# Altium schematic sheet SMP.SchDoc(SMP)
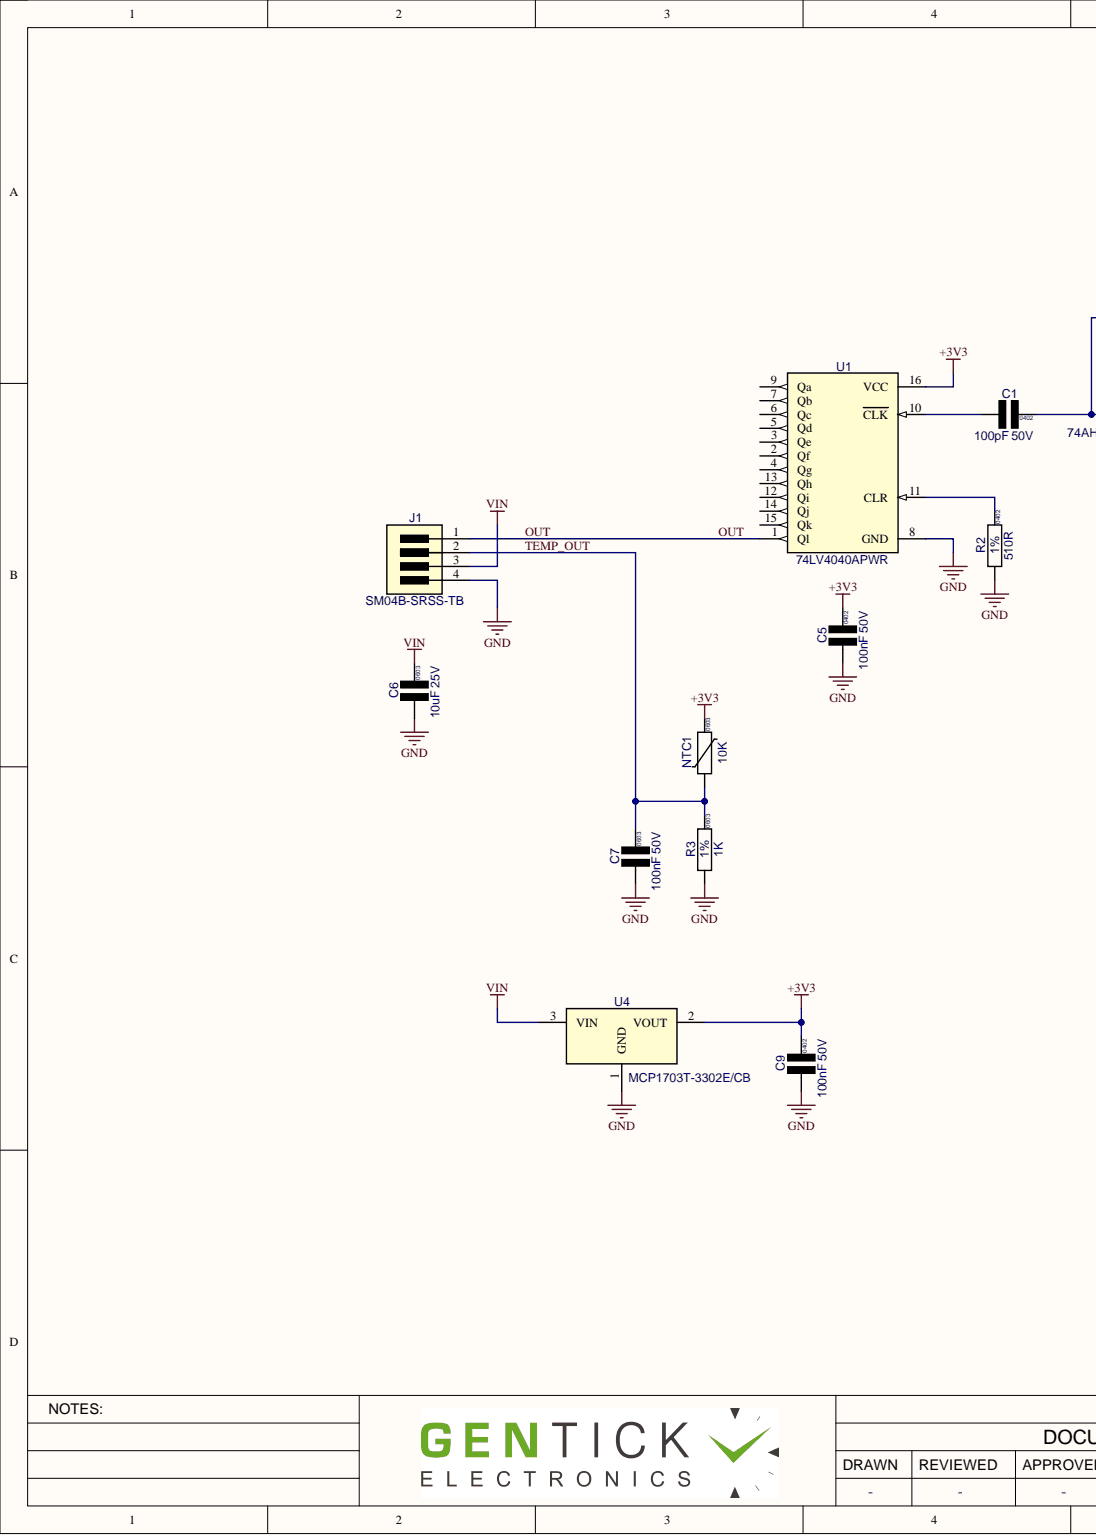
[diagram p0: SMP.SchDoc, left]
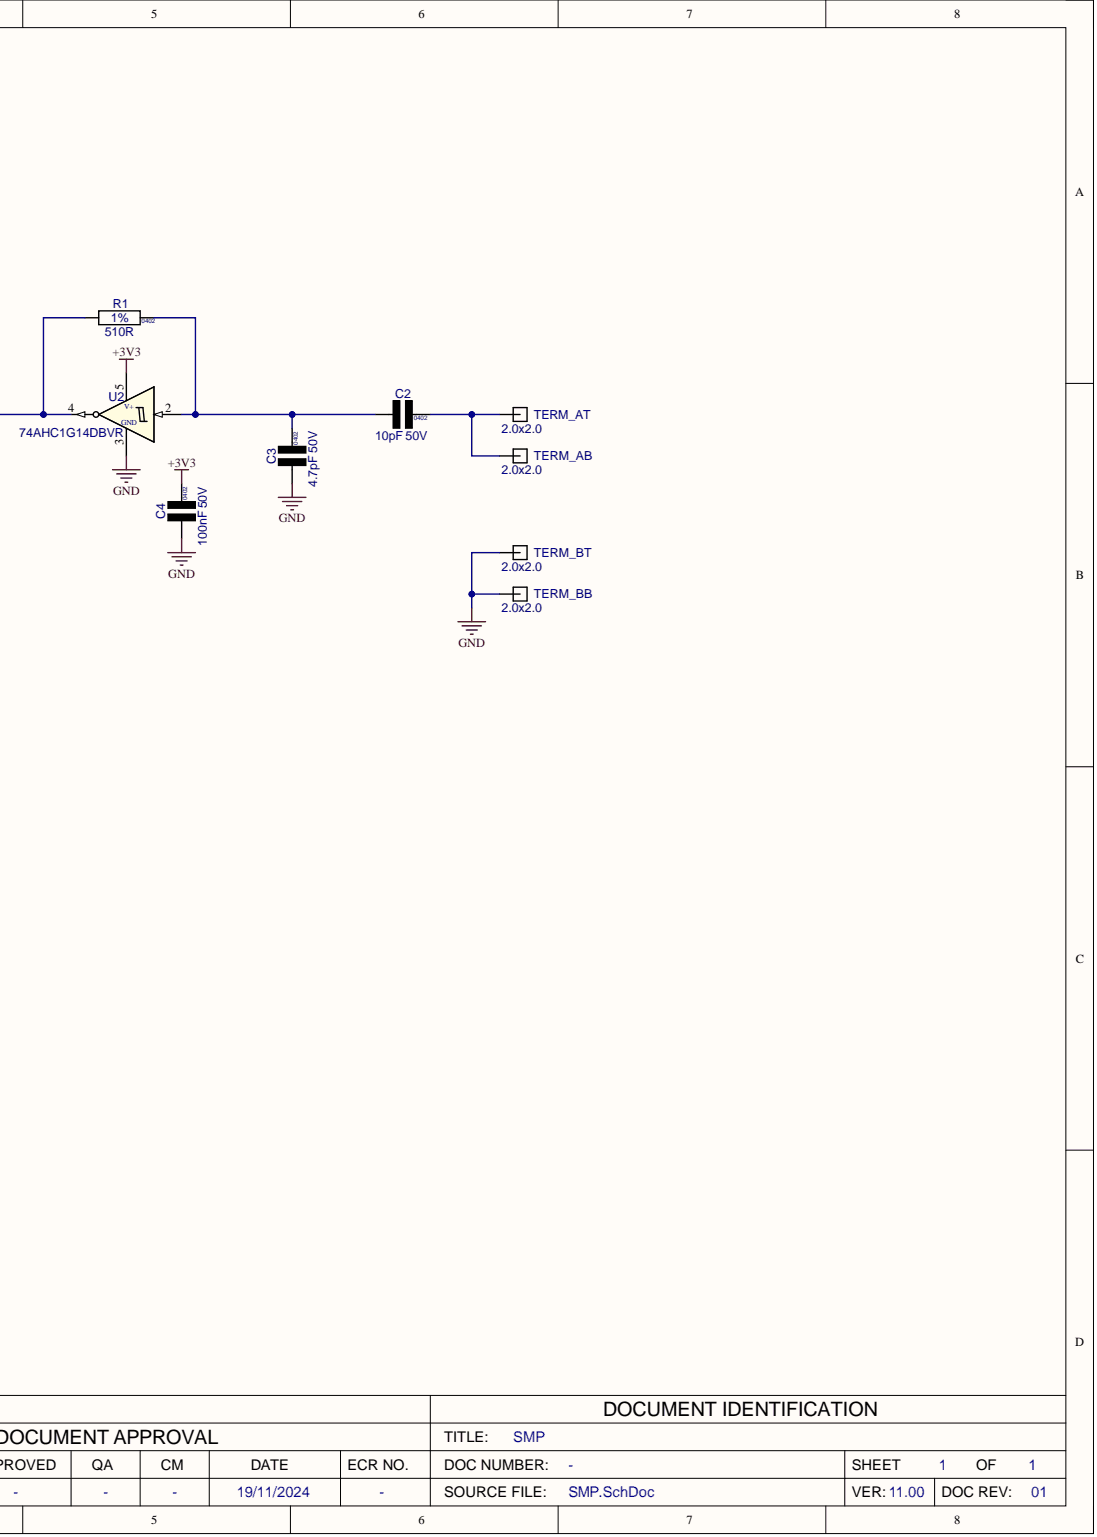
[diagram p1: SMP.SchDoc, right]

## Parts
C1  value 100pF  Text1: 0402  Voltage: 50V  [CAPC0402X60N]  (hidden comment: 100pF_C0402_50V_10%_C0G/NP0)
C2  value 10pF  Text1: 0402  Voltage: 50V  [CAPC0402X60N]  (hidden comment: 10pF_C0402_50V_5%_C0G/NP0)
C3  value 4.7pF  Text1: 0402  Voltage: 50V  [CAPC0402X60N]  (hidden comment: 4.7pF_C0402_50V_5%_C0G/NP0)
C4  value 100nF  Text1: 0402  Voltage: 50V  [CAPC0402X60N]  (hidden comment: 100nF_C0402_50V_5%_X5R)
C5  value 100nF  Text1: 0402  Voltage: 50V  [CAPC0402X60N]  (hidden comment: 100nF_C0402_50V_5%_X5R)
C6  value 10uF  Text1: 0603  Voltage: 25V  [CAPC0603X85N]  (hidden comment: 10uF_C0603_25V_10%_X5R)
C7  value 100nF  Text1: 0603  Voltage: 50V  [CAPC0603X85N]  (hidden comment: 100nF_C0603_50V_5%_X5R)
C9  value 100nF  Text1: 0402  Voltage: 50V  [CAPC0402X60N]  (hidden comment: 100nF_C0402_50V_5%_X5R)
J1  SM04B-SRSS-TB  [SM04B-SRSS-TB]
NTC1  value 10K  Text1: 0603  [NTC0603]  (hidden comment: ERT-J1VG103FA)
R1  value 510R  Part Number: 510R 0402 1%  Text1: 0402  Tol: 1%  [RESC0402X40N]  (hidden comment: 510R_R0402_1%)
R2  value 510R  Part Number: 510R 0402 1%  Text1: 0402  Tol: 1%  [RESC0402X40N]  (hidden comment: 510R_R0402_1%)
R3  value 1K  Part Number: 1K 0603 1%  Text1: 0603  Tol: 1%  [RESC0603X60N]  (hidden comment: 1K_R0603_1%)
TERM_AB  Part Number: 2.0x2.0  [PAD-SMD-2.0x2.0mm Rect]  (hidden comment: Pad-SMD-2.0x2.0mm-H_Rectangle)  (no BOM)
TERM_AT  Part Number: 2.0x2.0  [PAD-SMD-2.0x2.0mm Rect]  (hidden comment: Pad-SMD-2.0x2.0mm-H_Rectangle)  (no BOM)
TERM_BB  Part Number: 2.0x2.0  [PAD-SMD-2.0x2.0mm Rect]  (hidden comment: Pad-SMD-2.0x2.0mm-H_Rectangle)  (no BOM)
TERM_BT  Part Number: 2.0x2.0  [PAD-SMD-2.0x2.0mm Rect]  (hidden comment: Pad-SMD-2.0x2.0mm-H_Rectangle)  (no BOM)
U1  74LV4040APWR  [TSSOP16-4.5x5.0]
U2  74AHC1G14DBVR  [SOT23-5]
U4  MCP1703T-3302E/CB  [SOT23A]

## Nets
+3V3: C4.1 C5.1 C9.1 NTC1.2 U1.16(VCC) U2.5(V+) U4.2
GND: C3.2 C4.2 C5.2 C6.2 C7.2 C9.2 J1.4 R2.1 R3.1 TERM_BB.1 TERM_BT.1 U1.8(GND) U2.3 U4.1
VIN: C6.1 J1.3 U4.3
OUT: J1.1 U1.1(Ql)
TEMP_OUT: C7.1 J1.2 NTC1.1 R3.2
NetC1_1: C1.1 R1.1 U2.4
NetC1_2: C1.2 U1.10(CLK)
NetC2_1: C2.1 TERM_AB.1 TERM_AT.1
NetC2_2: C2.2 C3.1 R1.2 U2.2
NetR2_2: R2.2 U1.11(CLR)

Not connected: U1 2(Qf) 3(Qe) 4(Qg) 5(Qd) 6(Qc) 7(Qb) 9(Qa) 12(Qi) 13(Qh) 14(Qj) 15(Qk)

## Library data of the parts
C1 = 100pF_0402N_50V_10%_C0G/NP0: Cap Ceramic C0G/NP0; DCE Part No: 9732; Manufacturer: Various; Part Number: 100pF 0402 50V 10% C0G/NP0; EDM-PN: CAP802; Tol: 10%; Component Kind: Standard; Library Name: Cap-Cer-SMD_V2.IntLib; Package: CAPC0402X60N
C2 = 10pF_0402N_50V_5%_C0G/NP0: Cap Ceramic C0G/NP0; DCE Part No: 7194; Manufacturer: Various; Part Number: 10pF 0402 50V 5% C0G/NP0; EDM-PN: CAP1008; Tol: 5%; Component Kind: Standard; Library Name: Cap-Cer-SMD_V2.IntLib; Package: CAPC0402X60N
C3 = 4.7pF_0402N_50V_5%_C0G/NP0: Cap Ceramic C0G/NP0; Manufacturer: Various; Part Number: 4.7pF 0402 50V 5% C0G/NP0; EDM-PN: CAP1308; Tol: 5%; Component Kind: Standard; Library Name: Cap-Cer-SMD_V2.IntLib; Package: CAPC0402X60N
C4 C5 C9 = 100nF_0402N_50V_5%_X5R: Cap Ceramic X5R; Manufacturer: Various; Part Number: 100nF 0402 50V 5% X5R; Tol: 5%; Component Kind: Standard; Library Name: Cap-Cer-SMD_V2.IntLib; Package: CAPC0402X60N
C6 = 10uF_0603N_25V_10%_X5R: Cap Ceramic X5R; Manufacturer: Various; Part Number: 10uF 0603 25V 10% X5R; EDM-PN: CAP1460; Tol: 10%; Component Kind: Standard; Library Name: Cap-Cer-SMD_V2.IntLib; Package: CAPC0603X85N; Supplier 1: Digi-Key; Supplier Part Number 1: 490-18214-1-ND
C7 = 100nF_0603N_50V_5%_X5R: Cap Ceramic X5R; Manufacturer: Various; Part Number: 100nF 0603 50V 5% X5R; Tol: 5%; Component Kind: Standard; Library Name: Cap-Cer-SMD_V2.IntLib; Package: CAPC0603X85N
J1 = SM04B-SRSS-TB: Connector Wire to Board 1.0mm Rt Angle; Manufacturer: JST; Component Kind: Standard; Library Name: Connector-WtB.IntLib; Package: SM04B-SRSS-TB; Part Number: SM04B-SRSS-TB; Supplier 1: Digi-Key; Supplier Part Number 1: 455-SM04B-SRSS-TBCT-ND; Value: SM04B-SRSS-TB
NTC1 = NTC-10K-ERT-J1VG103FA: NTC Thermistor 0603; Manufacturer: Panasonic; Component Kind: Standard; Library Name: Protection_V2.IntLib; Package: NTC0603; Part Number: ERT-J1VG103FA
R1 R2 = 510R_0402: Resistor Chip SMD; Manufacturer: Various; EDM-PN: RES1498; Watt: 63mW; Component Kind: Standard; Library Name: Res-SMD_V2.IntLib; Package: RESC0402X40N
R3 = 1K_0603: Resistor Chip SMD; Manufacturer: Various; EDM-PN: RES122; Watt: 100mW; Component Kind: Standard; Library Name: Res-SMD_V2.IntLib; Package: RESC0603X60N; Reutech PN: 5905-93-1001
TERM_AB TERM_AT TERM_BB TERM_BT = Pad-SMD-2.0x2.0mm_Rectangle: Surface Mount Pad 2.0x2.0mm Rectangle; Manufacturer: NA; Value: SMD 2.0x2.0mm Rectangle; Library Name: Mechanical_V2.IntLib; Package: PAD-SMD-2.0x2.0mm Rect
U1 = 74LV4040APWR_TSSOP16: IC 12-Bit Binary Ripple Counter; Manufacturer: Texas; Component Kind: Standard; Package: TSSOP16-4.5x5.0; Part Number: 74LV4040APWR; Value: 74LV4040APWR; Library Name: IC-CMOS.IntLib
U2 = 74AHC1G14DBVR_SOT23-5: IC Single Schmitt-Trigger Inverter; Manufacturer: Texas; Component Kind: Standard; Library Name: IC-TTL.IntLib; Package: SOT23-5; Part Number: 74AHC1G14DBVR; Supplier 1: Digi-Key; Supplier Part Number 1: 296-1092-1-ND; Value: 74AHC1G14DBVR
U4 = MCP1703T-3302E/CB_SOT23A: Voltage Regulator LDO 250mA; Manufacturer: Microchip; EDM-PN: VRG191; Component Kind: Standard; Library Name: IC-Regulator.IntLib; Package: SOT23A; Part Number: MCP1703T-3302E/CB; Value: MCP1703T-3302E/CB
